# Altium schematic sheet KC1_2pouts.SchDoc(KC1_2pouts)
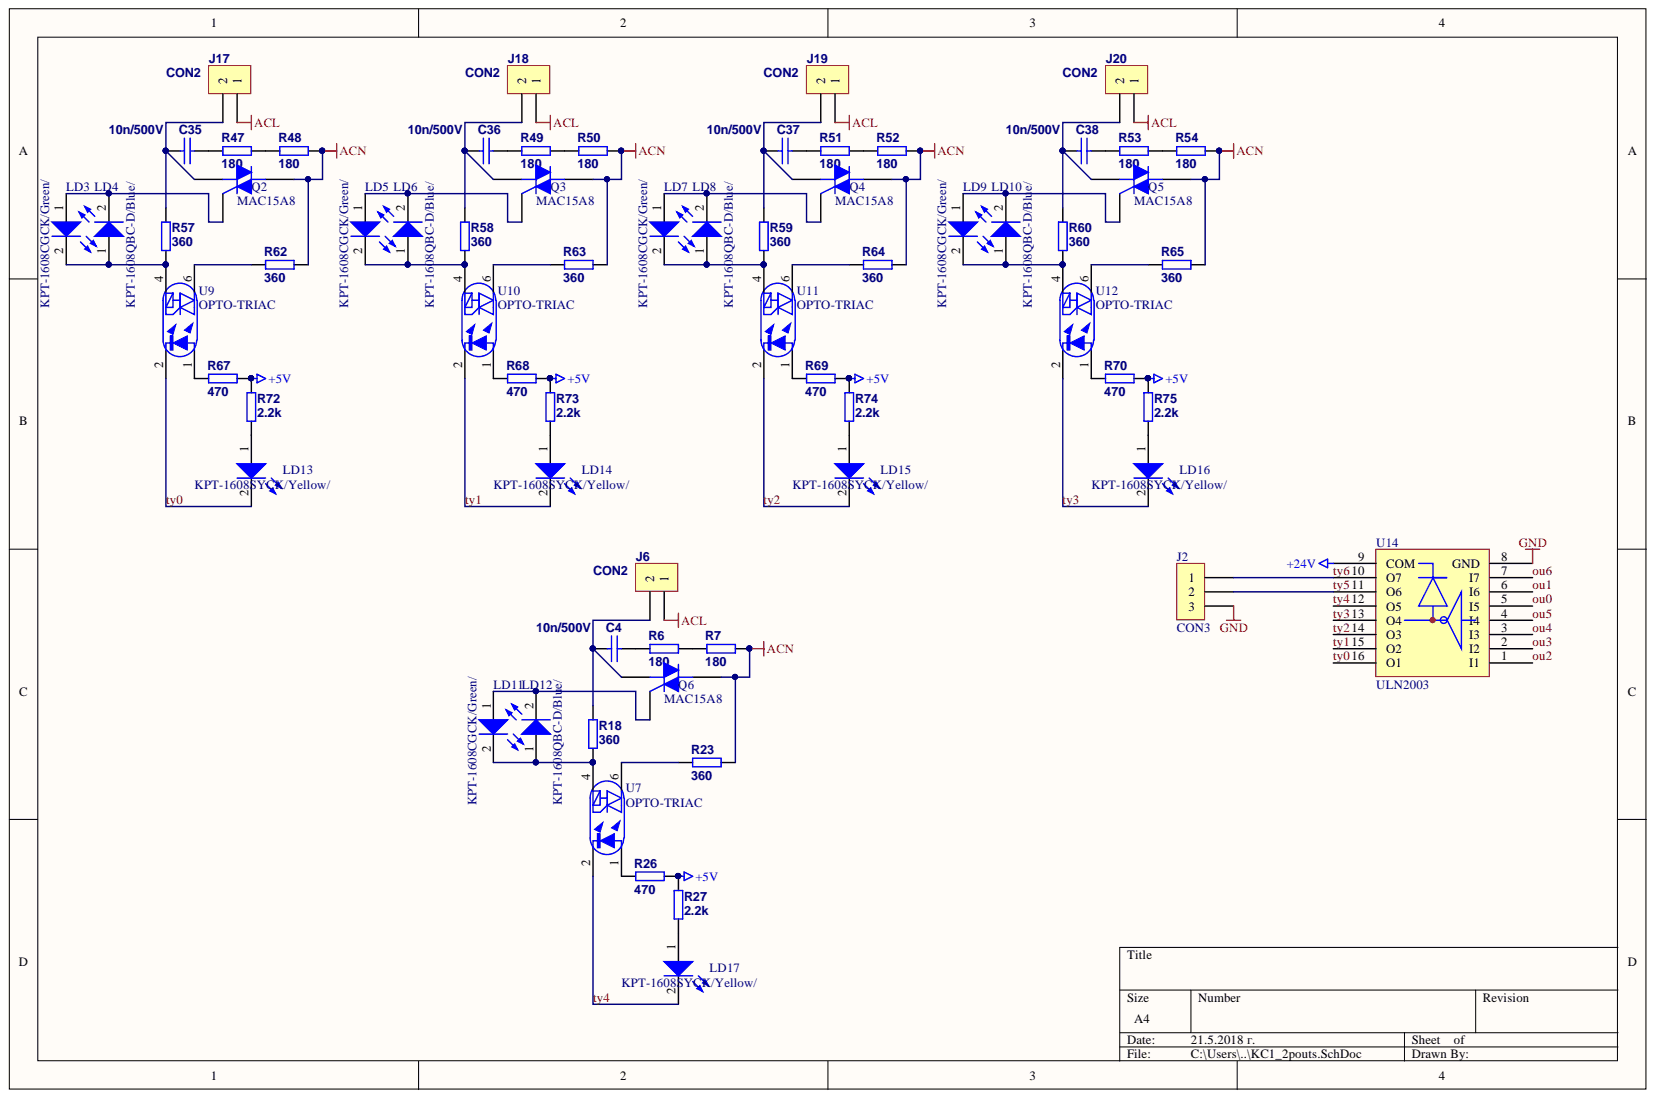
[diagram p0: KC1_2pouts.SchDoc]

## Parts
C4  10n/500V  [1206S]
C35  10n/500V  [1206S]
C36  10n/500V  [1206S]
C37  10n/500V  [1206S]
C38  10n/500V  [1206S]
J2  CON3  [CON3-MINI-5_00MM]
J6  CON2  [CON2-MINI]
J17  CON2  [CON2-MINI]
J18  CON2  [CON2-MINI]
J19  CON2  [CON2-MINI]
J20  CON2  [CON2-MINI]
LD3  KPT-1608CGCK/Green/  [0603D]
LD4  KPT-1608QBC-D/Blue/  [0603D]
LD5  KPT-1608CGCK/Green/  [0603D]
LD6  KPT-1608QBC-D/Blue/  [0603D]
LD7  KPT-1608CGCK/Green/  [0603D]
LD8  KPT-1608QBC-D/Blue/  [0603D]
LD9  KPT-1608CGCK/Green/  [0603D]
LD10  KPT-1608QBC-D/Blue/  [0603D]
LD11  KPT-1608CGCK/Green/  [0603D]
LD12  KPT-1608QBC-D/Blue/  [0603D]
LD13  KPT-1608SYCK/Yellow/  [0603D]
LD14  KPT-1608SYCK/Yellow/  [0603D]
LD15  KPT-1608SYCK/Yellow/  [0603D]
LD16  KPT-1608SYCK/Yellow/  [0603D]
LD17  KPT-1608SYCK/Yellow/  [0603D]
Q2  MAC15A8  [221A-09]
Q3  MAC15A8  [221A-09]
Q4  MAC15A8  [221A-09]
Q5  MAC15A8  [221A-09]
Q6  MAC15A8  [221A-09]
R6  180  [1206S]
R7  180  [1206S]
R18  360  [1206S]
R23  360  [1206S]
R26  470  [0805.PRT_0805.PRT]
R27  2.2k  [0603R]
R47  180  [1206S]
R48  180  [1206S]
R49  180  [1206S]
R50  180  [1206S]
R51  180  [1206S]
R52  180  [1206S]
R53  180  [1206S]
R54  180  [1206S]
R57  360  [1206S]
R58  360  [1206S]
R59  360  [1206S]
R60  360  [1206S]
R62  360  [1206S]
R63  360  [1206S]
R64  360  [1206S]
R65  360  [1206S]
R67  470  [0805.PRT_0805.PRT]
R68  470  [0805.PRT_0805.PRT]
R69  470  [0805.PRT_0805.PRT]
R70  470  [0805.PRT_0805.PRT]
R72  2.2k  [0603R]
R73  2.2k  [0603R]
R74  2.2k  [0603R]
R75  2.2k  [0603R]
U7  OPTO-TRIAC  [DIP-6]
U9  OPTO-TRIAC  [DIP-6]
U10  OPTO-TRIAC  [DIP-6]
U11  OPTO-TRIAC  [DIP-6]
U12  OPTO-TRIAC  [DIP-6]
U14  ULN2003  [SO-16_N]

## Nets
+5V: R26.1 R27.1 R67.1 R68.1 R69.1 R70.1 R72.1 R73.1 R74.1 R75.1
+24V: U14.9(COM)
ACL: J6.1 J17.1 J18.1 J19.1 J20.1
ACN: Q2.2 Q3.2 Q4.2 Q5.2 Q6.2 R7.2 R23.2 R48.2 R50.2 R52.2 R54.2 R62.2 R63.2 R64.2 R65.2
GND: J2.3 U14.8(GND)
OU0: U14.5(I5)
OU1: U14.6(I6)
OU2: U14.1(I1)
OU3: U14.2(I2)
OU4: U14.3(I3)
OU5: U14.4(I4)
OU6: U14.7(I7)
TY0: LD13.2 U9.2 U14.16(O1)
TY1: LD14.2 U10.2 U14.15(O2)
TY2: LD15.2 U11.2 U14.14(O3)
TY3: LD16.2 U12.2 U14.13(O4)
TY4: LD17.2 U7.2 U14.12(O5)
TY5: J2.2 U14.11(O6)
TY6: J2.1 U14.10(O7)
NetC4_1: C4.1 J6.2 Q6.1 R18.1
NetC4_2: C4.2 R6.1
NetC35_1: C35.1 J17.2 Q2.1 R57.1
NetC35_2: C35.2 R47.1
NetC36_1: C36.1 J18.2 Q3.1 R58.1
NetC36_2: C36.2 R49.1
NetC37_1: C37.1 J19.2 Q4.1 R59.1
NetC37_2: C37.2 R51.1
NetC38_1: C38.1 J20.2 Q5.1 R60.1
NetC38_2: C38.2 R53.1
NetLD3_1: LD3.1 LD4.2 Q2.3
NetLD3_2: LD3.2 LD4.1 R57.2 U9.4
NetLD5_1: LD5.1 LD6.2 Q3.3
NetLD5_2: LD5.2 LD6.1 R58.2 U10.4
NetLD7_1: LD7.1 LD8.2 Q4.3
NetLD7_2: LD7.2 LD8.1 R59.2 U11.4
NetLD9_1: LD9.1 LD10.2 Q5.3
NetLD9_2: LD9.2 LD10.1 R60.2 U12.4
NetLD11_1: LD11.1 LD12.2 Q6.3
NetLD11_2: LD11.2 LD12.1 R18.2 U7.4
NetLD13_1: LD13.1 R72.2
NetLD14_1: LD14.1 R73.2
NetLD15_1: LD15.1 R74.2
NetLD16_1: LD16.1 R75.2
NetLD17_1: LD17.1 R27.2
NetR6_2: R6.2 R7.1
NetR23_1: R23.1 U7.6
NetR26_2: R26.2 U7.1
NetR47_2: R47.2 R48.1
NetR49_2: R49.2 R50.1
NetR51_2: R51.2 R52.1
NetR53_2: R53.2 R54.1
NetR62_1: R62.1 U9.6
NetR63_1: R63.1 U10.6
NetR64_1: R64.1 U11.6
NetR65_1: R65.1 U12.6
NetR67_2: R67.2 U9.1
NetR68_2: R68.2 U10.1
NetR69_2: R69.2 U11.1
NetR70_2: R70.2 U12.1

## Library data of the parts
C4 C35-C38 = CAP: Capacitor; Component Kind: Standard
J2 = CON3: Connector; Component Kind: Standard
J6 J17-J20 = CON2: Connector; Component Kind: Standard
LD3-LD17 = LED2: Typical RED, GREEN, YELLOW, AMBER GaAs LED; Component Kind: Standard; Library Name: Miscellaneous Devices.IntLib; Published: 8-Jun-2000; Revision: July-2002: Re-released for DXP Platform.; Publisher: Altium Limited
Q2-Q6 = MAC15A8: Silicon Bidirectional Triode Thyristor; Note: Pin 2 is electrically connected to Pin 4 (TAB); Code_JEDEC: TO-262-AA; Component Kind: Standard; ComponentLink1Description: Manufacturer Link; ComponentLink1URL: http://www.onsemi.com/site/products/landing?taxonomyID=194; ComponentLink2Description: Datasheet; ComponentLink2URL: http://www.onsemi.com/pub/Collateral/MAC15A4-D.PDF; DatasheetVersion: 1999; LatestRevisionDate: 17-Jul-2002; LatestRevisionNote: Re-released for DXP Platform.; PackageDescription: TO, Thru-Hole, Vertical, Heatsink Mounted; 3 In-Line Leads; Pitch 2.54 mm; PackageReference: 221A-09; PackageVersion: 1997; Published: 29-Sep-2000; Simulation: MAC15A8; Library Name: Motorola Discrete TRIAC.IntLib; Publisher: Altium Limited
R6 R7 R18 R23 R26 R27 R47-R54 R57-R60 R62-R65 R67-R70 R72-R75 = RES: Component Kind: Standard
U7 U9-U12 = OPTO-TRIAC: Opto triac with ZC detector; Component Kind: Standard; Library Name: OptoRu.SCHLIB
U14 = ULN2003: Component Kind: Standard; Library Name: Logic&BufRu.SCHLIB
